# Altium schematic sheet Terra_Spray.SchDoc(Terra_Spray)
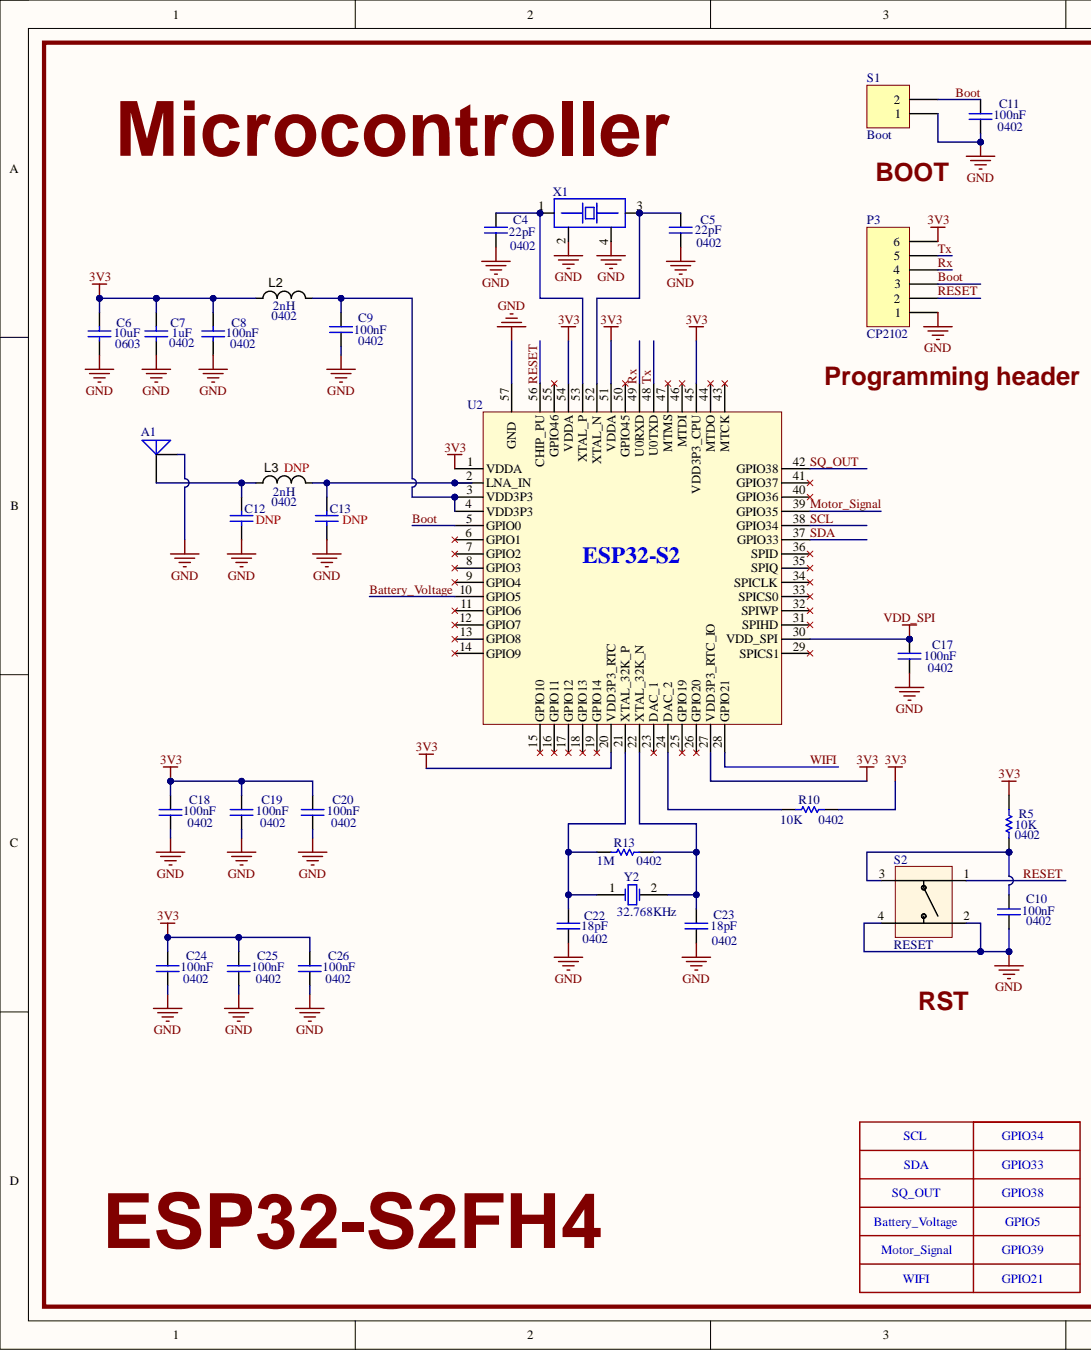
[diagram p0: Terra_Spray.SchDoc, left]
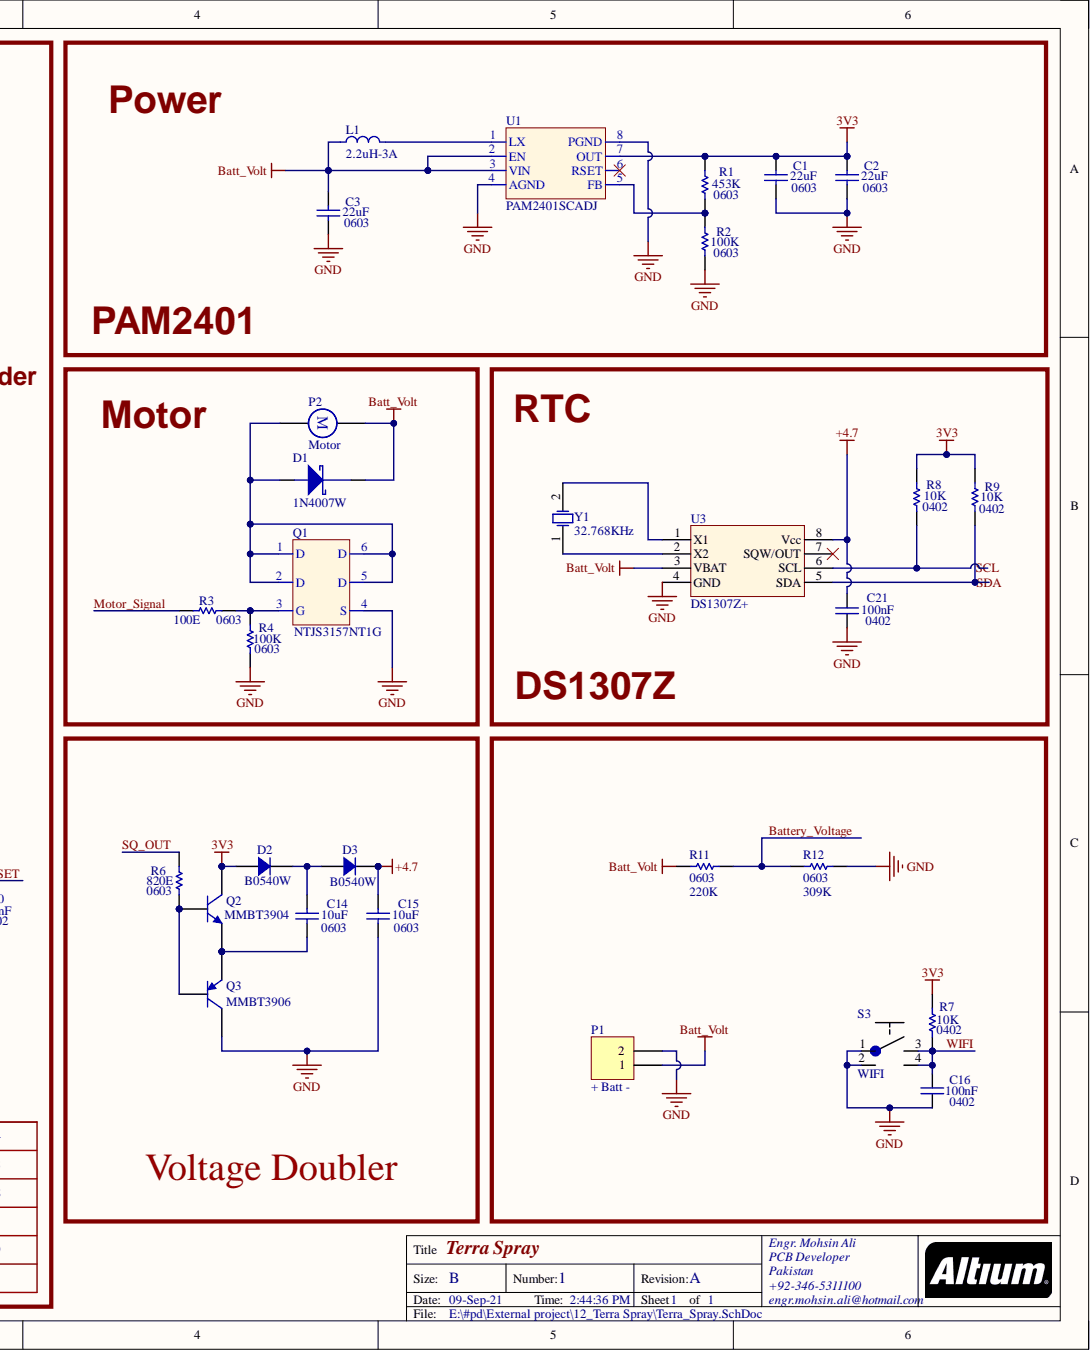
[diagram p1: Terra_Spray.SchDoc, right]

## Parts
A1  Antenna_1  [ESP32 ANT]  (value not drawn)
C1  value 22uF  Case/Package: 0603  [CAPC0603]  (hidden comment: Capacitor)
C2  value 22uF  Case/Package: 0603  [CAPC0603]  (hidden comment: Capacitor)
C3  value 22uF  Case/Package: 0603  [CAPC0603]  (hidden comment: Capacitor)
C4  value 22pF  Case/Package: 0402  [capc0402]  (hidden comment: Cap)
C5  value 22pF  Case/Package: 0402  [capc0402]  (hidden comment: Cap)
C6  value 10uF  Case/Package: 0603  [CAPC0603]  (hidden comment: Capacitor)
C7  value 1uF  Case/Package: 0402  [CAPC0402]  (hidden comment: Capacitor)
C8  value 100nF  Case/Package: 0402  [CAPC0402]  (hidden comment: Cap)
C9  value 100nF  Case/Package: 0402  [CAPC0402]  (hidden comment: Cap)
C10  value 100nF  Case/Package: 0402  [CAPC0402]  (hidden comment: Cap)
C11  value 100nF  Case/Package: 0402  [CAPC0402]  (hidden comment: Cap)
C12  Case/Package: 0402  [CAPC0402]  (hidden comment: Capacitor)  (no BOM)
C13  Capacitor  [CAPC0402]  (value not drawn)  (no BOM)
C14  value 10uF  Case/Package: 0603  [CAPC0603]  (hidden comment: Capacitor)
C15  value 10uF  Case/Package: 0603  [CAPC0603]  (hidden comment: Capacitor)
C16  value 100nF  Case/Package: 0402  [CAPC0402]  (hidden comment: Cap)
C17  value 100nF  Case/Package: 0402  [CAPC0402]  (hidden comment: Cap)
C18  value 100nF  Case/Package: 0402  [CAPC0402]  (hidden comment: Cap)
C19  value 100nF  Case/Package: 0402  [CAPC0402]  (hidden comment: Cap)
C20  value 100nF  Case/Package: 0402  [CAPC0402]  (hidden comment: Cap)
C21  value 100nF  Case/Package: 0402  [CAPC0402]  (hidden comment: Cap)
C22  value 18pF  Case/Package: 0402  [capc0402]  (hidden comment: Cap)
C23  value 18pF  Case/Package: 0402  [capc0402]  (hidden comment: Cap)
C24  value 100nF  Case/Package: 0402  [CAPC0402]  (hidden comment: Cap)
C25  value 100nF  Case/Package: 0402  [CAPC0402]  (hidden comment: Cap)
C26  value 100nF  Case/Package: 0402  [CAPC0402]  (hidden comment: Cap)
D1  1N4007W  [SOD-123]
D2  B0540W  [SOD123 - duplicate]
D3  B0540W  [SOD123 - duplicate]
L1  value 2.2uH-3A  [INDP5050X20N]  (hidden comment: Inductor)
L2  Case Code (Imperial): 0402  Inductance: 2nH  [inductor 0402]  (hidden comment: Inductor)
L3  Case Code (Imperial): 0402  Inductance: 2nH  [inductor 0402]  (hidden comment: Inductor)  (no BOM)
P1  + Batt -  [2 pin 2.5mm - Header]
P2  Motor  [2 pin 2.5mm - Header]
P3  CP2102  [Header 6x1]
Q1  Mosfet  [SOT-363]  (value not drawn)
Q2  Manufacturer Part Number: MMBT3904  [SOT-23]  (hidden comment: NPN BJT Transistor)
Q3  Manufacturer Part Number: MMBT3906  [SOT-23]  (hidden comment: PNP BJT Transistor)
R1  value 453K  Case/Package: 0603  [RESC0603]  (hidden comment: Resistor)
R2  value 100K  Case/Package: 0603  [RESC0603]  (hidden comment: Resistor)
R3  value 100E  Case/Package: 0603  [RESC0603]  (hidden comment: Resistor)
R4  value 100K  Case/Package: 0603  [RESC0603]  (hidden comment: Resistor)
R5  value 10K  Case/Package: 0402  [RESC0402]  (hidden comment: Resistor)
R6  value 820E  Case/Package: 0603  [RESC0603]  (hidden comment: Resistor)
R7  value 10K  Case/Package: 0402  [RESC0402]  (hidden comment: Resistor)
R8  value 10K  Case/Package: 0402  [RESC0402]  (hidden comment: Resistor)
R9  value 10K  Case/Package: 0402  [RESC0402]  (hidden comment: Resistor)
R10  value 10K  Case/Package: 0402  [RESC0402]  (hidden comment: Resistor)
R11  value 220K  Case/Package: 0603  [RESC0603]  (hidden comment: Resistor)
R12  value 309K  Case/Package: 0603  [RESC0603]  (hidden comment: Resistor)
R13  value 1M  Case/Package: 0402  [RESC0402]  (hidden comment: Resistor)
S1  Boot  [Switch Replacement]
S2  value RESET  [434153017835]  (hidden comment: Button)
S3  WIFI  [FSMJ - SMD - Wifi]
U1  PAM2401SCADJ  [8-TSSOP, 8-MSOP]
U2  ESP32-S2  [QFN56-7x7mm]
U3  DS1307Z+  Series: DS1307Z  [SOIC-8]
X1  CRYSTAL_1  [Crystal_3225]  (value not drawn)
Y1  32.768KHz  [32.728Khz]
Y2  32.768KHz  [32.728Khz]

## Nets
3V3: C1.2 C2.2 C6.2 C7.2 C8.2 C18.1 C19.1 C20.1 C24.1 C25.1 C26.1 D2.A L2.1 P3.6 Q2.3 R1.2 R5.2 R7.2 R8.2 R9.2 R10.1 U1.7(OUT) U2.1(VDDA) U2.20(VDD3P3_RTC) U2.27(VDD3P3_RTC_IO) U2.45(VDD3P3_CPU) U2.51(VDDA) U2.54(VDDA)
+4.7: C15.1 C21.1 D3.K U3.8(Vcc)
Batt_Volt: C3.2 D1.1 L1.1 P1.1 P2.1 R11.2 U1.2(EN) U1.3(VIN) U3.3(VBAT)
GND: A1.2 C1.1 C2.1 C3.1 C4.1 C5.1 C6.1 C7.1 C8.1 C9.2 C10.2 C11.1 C12.1 C13.1 C15.2 C16.1 C17.2 C18.2 C19.2 C20.2 C21.2 C22.1 C23.1 C24.2 C25.2 C26.2 P1.2 P3.1 Q1.4(S) Q3.3 R2.2 R4.2 R12.1 S1.1 S2.2 S2.4 S3.1 S3.2 U1.4(AGND) U1.8(PGND) U2.57(GND) U3.4(GND) X1.2 X1.4
VDD_SPI: C17.1 U2.30(VDD_SPI)
Battery_Voltage: R11.1 R12.2 U2.10(GPIO5)
Boot: C11.2 P3.3 S1.2 U2.5(GPIO0)
Motor_Signal: R3.2 U2.39(GPIO35)
RESET: P3.2 S2.1 U2.56(CHIP_PU)
Rx: P3.4 U2.49(U0RXD)
SCL: R8.1 U2.38(GPIO34) U3.6(SCL)
SDA: R9.1 U2.37(GPIO33) U3.5(SDA)
SQ_OUT: R6.2 U2.42(GPIO38)
Tx: P3.5 U2.48(U0TXD)
WIFI: C16.2 R7.1 S3.3 S3.4 U2.28(GPIO21)
NetA1_1: A1.1 C12.2 L3.1
NetC4_2: C4.2 U2.53(XTAL_P) X1.1
NetC5_2: C5.2 U2.52(XTAL_N) X1.3
NetC9_1: C9.1 L2.2 U2.3(VDD3P3) U2.4(VDD3P3)
NetC10_1: C10.1 R5.1 S2.3
NetC13_2: C13.2 L3.2 U2.2(LNA_IN)
NetC14_1: C14.1 D2.K D3.A
NetC14_2: C14.2 Q2.2 Q3.2
NetC22_2: C22.2 R13.1 U2.21(XTAL_32K_P) Y2.1
NetC23_2: C23.2 R13.2 U2.22(XTAL_32K_N) Y2.2
NetD1_2: D1.2 P2.2 Q1.1(D) Q1.2(D) Q1.5(D) Q1.6(D)
NetL1_2: L1.2 U1.1(LX)
NetQ1_3: Q1.3(G) R3.1 R4.1
NetQ2_1: Q2.1 Q3.1 R6.1
NetR1_1: R1.1 R2.1 U1.5(FB)
NetR10_2: R10.2 U2.24(DAC_2)
NetU3_1: U3.1(X1) Y1.2
NetU3_2: U3.2(X2) Y1.1
GPIO5:
GPIO21:
GPIO33:
GPIO34:
GPIO38:
GPIO39:

Not connected: U1 6(RSET); U2 6(GPIO1) 7(GPIO2) 8(GPIO3) 9(GPIO4) 11(GPIO6) 12(GPIO7) 13(GPIO8) 14(GPIO9) 15(GPIO10) 16(GPIO11) 17(GPIO12) 18(GPIO13) 19(GPIO14) 23(DAC_1) 25(GPIO19) 26(GPIO20) 29(SPICS1) 31(SPIHD) 32(SPIWP) 33(SPICS0) 34(SPICLK) 35(SPIQ) 36(SPID) 40(GPIO36) 41(GPIO37) 43(MTCK) 44(MTDO) 46(MTDI) 47(MTMS) 50(GPIO45) 55(GPIO46); U3 7(SQW/OUT)

## Library data of the parts
A1 = Antenna_1: PCB Antenna; Component Kind: Standard; LatestRevisionDate: 17-Jul-2002; LatestRevisionNote: Re-released for DXP Platform.; Library Name: Pd's Sch Lib.SchLib; PackageReference: PIN1; Published: 24-Mar-1999; Publisher: Altium Limited
C1-C3 = Capacitor: Ceramic Capacitor,22uF,0603,6.3V; Component Kind: Standard; Library Name: Pd's Sch Lib.SchLib
C4 C5 = Cap: Ceramic Capacitor,22pF,0402,50V; Component Kind: Standard; Library Name: CUSTOM TNY290PG.SchLib; Signal Integrity: Cap Pol; Simulation: CAP
C6 C14 C15 = Capacitor: Ceramic Capacitor,10uF,0603,10V; Component Kind: Standard; Library Name: Pd's Sch Lib.SchLib
C7 = Capacitor: Ceramic Capacitor,1uF,0402,6.3V; Component Kind: Standard; Library Name: Pd's Sch Lib.SchLib
C8 = Capacitor: Ceramic Capacitor,100nF,0402,6.3V; Component Kind: Standard; Library Name: Pd's Sch Lib.SchLib
C9 C10 C17-C20 C24-C26 = Cap: Ceramic Capacitor,100nF,0402,6.3V; Component Kind: Standard; Library Name: CUSTOM TNY290PG.SchLib
  C9 C10 C18-C20 C24-C26: Signal Integrity: Cap Pol; Simulation: CAP
C11 = Cap: Ceramic Capacitor,100nF,0402,16V; Component Kind: Standard; Library Name: CUSTOM TNY290PG.SchLib; Signal Integrity: Cap Pol; Simulation: CAP
C16 C21 = Cap: Ceramic Capacitor,100nF,0402,6.3  V; Component Kind: Standard; Library Name: CUSTOM TNY290PG.SchLib; Signal Integrity: Cap Pol; Simulation: CAP
C22 C23 = Cap: Ceramic Capacitor,18pF,0402,50V; Component Kind: Standard; Library Name: CUSTOM TNY290PG.SchLib; Signal Integrity: Cap Pol; Simulation: CAP
D1 = BAT54HT1G: Rectifiers RECTIFIER DIODE 1A 1000V; Part No: CGRM4007-G; Component Kind: Standard; ComponentLink1Description: http://www.fairchildsemi.com/ds/BA/BAT54HT1G.pdf; ComponentLink1URL: http://www.fairchildsemi.com/ds/BA/BAT54HT1G.pdf; Library Name: Integrated_Library_FEDEVEL_APR_2015.IntLib
D2 D3 = Diode: Diode Schottky 40V 0.5A 2-Pin SOD-123 T/R; Manufacturer 1: Diodes; Average Rectified Current: 500mA; Element Configuration: Single; Forward Voltage: 620mV; Max Forward Surge Current (Ifsm): 5.5A; Max Power Dissipation: 410mW; Max Reverse Current: 20uA; Component Kind: Standard; Forward Current: 500mA; Height: 1.25mm; Lead Free: Lead Free; Length: 2.85mm; Library Name: Pd's Sch Lib.SchLib; Manufacturer Part Number: B0540W; Max Operating Temperature: 150°C; Max Repetitive Reverse Voltage (Vrrm): 40V; Max Reverse Voltage (DC): 40V; Min Operating Temperature: -65°C; Mount: Surface Mount; Number of Pins: 2; Output Current: 500mA; Package Quantity: 3000
L1 = 74404052022: WURTH ELEKTRONIK - 74404052022 - Power Inductor (SMD), 2.2 µH, 3 A, Semishielded, 3.6 A, WE-LQS Series, 5mm x 5mm x 2mm; Manufacturer 1: Wurth Electronics; Current Rating: 3A; DC Resistance (DCR): 31.6mR; Inductance: 2.2uH; Saturation Current: 3.6A; Self Resonant Frequency: 88MHz; Test Frequency: 100kHz; Tolerance: 30%; Case/Package: Nonstandard; Component Kind: Standard; ComponentLink1Description: http://www.farnell.com/datasheets/2618696.pdf?src-supplier=Farnell; ComponentLink1URL: http://www.farnell.com/datasheets/2618696.pdf?src-supplier=Farnell; ComponentLink2Description: https://katalog.we-online.de/pbs/datasheet/74404052022.pdf?src-supplier=Digi-Key; ComponentLink2URL: https://katalog.we-online.de/pbs/datasheet/74404052022.pdf?src-supplier=Digi-Key; ComponentLink3Description: http://www.farnell.com/datasheets/2618696.pdf?src-supplier=Newark; ComponentLink3URL: http://www.farnell.com/datasheets/2618696.pdf?src-supplier=Newark; DigiKey: 732-7241-1-ND; Height - Seated (Max): 0.079inch; Length: 0.197inch; Library Name: Inductors.SchLib; Max Operating Temperature: 125°C; Min Operating Temperature: -40°C; Mount: Surface Mount; P/N: 74404052022; Packaging: Tape and Reel; Pricing 1: 1=1.23000, 50=1.13100, 250=1.06400, 500=0.95200, 1000=0.78400 (USD); Resistor Type: Wirewound; RoHS: Yes; Shielding: Shielded; Stock 1: 1338; Supplier 1: Digi-Key; Width: 0.197inch
L2 L3 = Inductor: 2nH ±0.3nH 900mA 120mΩ 0402 Inductors (SMD) RoHS; Manufacturer 1: Murata; Manufacturer Part Number: LQG15HN2N0S02D; Category 1: Fixed Inductors; Core Material: Air; DC Resistance (DCR): 120mR; Description 1: Fixed Inductors 0402 2.0nH; Q Factor: 8; Self Resonant Frequency: 6GHz; Shielding: Unshielded; Test Frequency: 100MHz; Case Code (Metric): 1005; Case/Package: 0402; ComponentLink1Description: https://datasheet.ciiva.com/11799/0900766b8090d3b8-11799042.pdf?src-supplier=RSComponents; ComponentLink1URL: https://datasheet.ciiva.com/11799/0900766b8090d3b8-11799042.pdf?src-supplier=RSComponents; ComponentLink2Description: https://datasheet.ciiva.com/4976/o05e-4976477.pdf?src-supplier=Mouser; ComponentLink2URL: https://datasheet.ciiva.com/4976/o05e-4976477.pdf?src-supplier=Mouser; ComponentLink3Description: https://datasheet.ciiva.com/8510/l0075s0083lqg15hn-8510907.pdf?src-supplier=Avnet; ComponentLink3URL: https://datasheet.ciiva.com/8510/l0075s0083lqg15hn-8510907.pdf?src-supplier=Avnet; ComponentLink4Description: https://datasheet.ciiva.com/17279/l0075s0083lqg15hn-17279196.pdf?src-supplier=Avnet+Europe; ComponentLink4URL: https://datasheet.ciiva.com/17279/l0075s0083lqg15hn-17279196.pdf?src-supplier=Avnet+Europe; Current: 900mA; Current Rating: 900mA; DC Current: 900mA; Depth: 0.55mm; Height: 0.5mm; Height - Seated (Max): 0.022inch; Length: 1mm; Library Name: Pd's Sch Lib.SchLib; Max DC Current: 900mA; Max Operating Temperature: 125°C; Military Standard: Not; Min Operating Temperature: -55°C; Mount: Surface Mount; Number of Pins: 2; Package Quantity: 10000; Packaging: Tape and Reel; Pricing 1: 50=0.00840, 500=0.00620, 1500=0.00580, 5000=0.00540, 25000=0.00520, 50000=0.00520, 50=0.00810, 500=0.00600, 1500=0.00560, 5000=0.00520, 25000=0.00500, 50000=0.00500, 50=0.04180, 500=0.03090, 1500=0.02890, 5000=0.02690, 25000=0.02600, 50000=0.02550, 50=0.03860, 500=0.02850, 1500=0.02670, 5000=0.02480, 25000=0.02400, 50000=0.02360, 50=0.00520, 500=0.00380, 1500=0.00360, 5000=0.00330, 25000=0.00320, 50000=0.00320, 50=0.00480, 500=0.00350, 1500=0.00330, 5000=0.00310, 25000=0.00300, 50000=0.00290, 50=0.04770, 500=0.03530, 1500=0.03300, 5000=0.03070, 25000=0.02970, 50000=0.02920, 50=0.65070, 500=0.48080, 1500=0.44960, 5000=0.41830, 25000=0.40450, 50000=0.39760, 50=0.02550, 500=0.01880, 1500=0.01760, 5000=0.01640, 25000=0.01580, 50000=0.01560, 50=0.46320, 500=0.34230, 1500=0.32010, 5000=0.29780, 25000=0.28800, 50000=0.28310, 50=0.05400, 500=0.03990, 1500=0.03730, 5000=0.03470, 25000=0.03360, 50000=0.03300, 50=0.00840, 500=0.00620, 1500=0.00580, 5000=0.00540, 25000=0.00520, 50000=0.00510, 50=0.00616, 500=0.00455, 1500=0.00426, 5000=0.00396, 25000=0.00383, 50000=0.00376 (AUD); Radiation Hardening: No; REACH SVHC: No SVHC; RoHS 1: Yes; Stock 1: 84500; Supplier 1: LCSC; Supplier Part Number 1: C97984; Termination: SMD/SMT; Width: 0.02inch
  L2: Component Kind: Standard
P1 S1 = Header 2: Header, 2-Pin; Component Kind: Standard; LatestRevisionDate: 17-Jul-2002; LatestRevisionNote: Re-released for DXP Platform.; Library Name: Miscellaneous Connectors.IntLib; Signal Integrity: Connector; Publisher: Altium Limited
P2 = Motor: Motor, General Kind; Component Kind: Standard; LatestRevisionDate: 17-Jul-2002; LatestRevisionNote: Re-released for DXP Platform.; Library Name: Miscellaneous Devices.IntLib; PackageDescription: Capacitor (Radial); 2 Leads; PackageReference: RB5-10.5; Published: 24-Mar-1999; Publisher: Altium Limited
P3 = Header 6: Header, 6-Pin; Component Kind: Standard; LatestRevisionDate: 17-Jul-2002; LatestRevisionNote: Re-released for DXP Platform.; Library Name: Miscellaneous Connectors.IntLib; Signal Integrity: Connector; Publisher: Altium Limited
Q1 = NTJS3157NT1G: N-Channel 20V 3.2A (Ta) 1W (Ta) Surface Mount SC-88/SC70-6/SOT-363; Component Kind: Standard; Part No: NTJS3157NT1G; Library Name: Terra_Spray.SchLib
Q2 = NPN BJT Transistor: Bipolar Transistors - BJT SOT-23 NPN; Current Rating: 200mA; Max Breakdown Voltage: 40V; Max Power Dissipation: 350mW; Case/Package: SOT-23; Category: BJTs; Component Kind: Standard; ComponentLink1Description: https://datasheet.ciiva.com/971/mmbt3904-971892.pdf?src-supplier=Digi-Key; ComponentLink1URL: https://datasheet.ciiva.com/971/mmbt3904-971892.pdf?src-supplier=Digi-Key; ComponentLink2Description: https://datasheet.ciiva.com/971/mmbt3904-971893.pdf?src-supplier=Digi-Key; ComponentLink2URL: https://datasheet.ciiva.com/971/mmbt3904-971893.pdf?src-supplier=Digi-Key; ComponentLink3Description: https://datasheet.ciiva.com/22653/1922793-22653482.pdf?src-supplier=Element14; ComponentLink3URL: https://datasheet.ciiva.com/22653/1922793-22653482.pdf?src-supplier=Element14; ComponentLink4Description: https://datasheet.ciiva.com/971/mmbt3904-971891.pdf?src-supplier=Digi-Key; ComponentLink4URL: https://datasheet.ciiva.com/971/mmbt3904-971891.pdf?src-supplier=Digi-Key; ComponentLink5Description: https://datasheet.ciiva.com/26237/mmbt3904-26237242.pdf?src-supplier=Avnet+Europe; ComponentLink5URL: https://datasheet.ciiva.com/26237/mmbt3904-26237242.pdf?src-supplier=Avnet+Europe; ComponentLink6Description: https://datasheet.ciiva.com/7972/2n3904-7972669.pdf?src-supplier=Element14; ComponentLink6URL: https://datasheet.ciiva.com/7972/2n3904-7972669.pdf?src-supplier=Element14; ComponentLink7Description: https://datasheet.ciiva.com/16850/mmbt3904-16850397.pdf?src-supplier=Future+Electronics; ComponentLink7URL: https://datasheet.ciiva.com/16850/mmbt3904-16850397.pdf?src-supplier=Future+Electronics; ComponentLink8Description: https://datasheet.ciiva.com/11201/mmbt3904-11201775.pdf?src-supplier=Avnet; ComponentLink8URL: https://datasheet.ciiva.com/11201/mmbt3904-11201775.pdf?src-supplier=Avnet; Library Name: Pd's Sch Lib.SchLib; Max Collector Current: 200mA; Min Operating Temperature: -55°C; Mount: Surface Mount; Polarity: NPN; Power Dissipation: 350mW; Termination: SMD/SMT
Q3 = PNP BJT Transistor: ON SEMICONDUCTOR - MMBT3906LT1G - Bipolar (BJT) Single Transistor, General Purpose, PNP, -40 V, 250 MHz, 225 mW, -200 mA, 300 hFE; Collector Base Voltage (VCBO): 40V; Collector Emitter Saturation Voltage: -400mV; Current Rating: -200mA; Emitter Base Voltage (VEBO): 5V; Frequency: 250MHz; hFE Min: 60; Max Collector Current: 200mA; Max Power Dissipation: 300mW; Number of Elements: 1; Voltage Rating (DC): -40V; Case/Package: SOT-23-3; Category: BJTs; Collector Emitter Breakdown Voltage: 40V; Collector Emitter Voltage (VCEO): 40V; Component Kind: Standard; ComponentLink1Description: Manufacturer Link; ComponentLink1URL: http://www.fairchildsemi.com/; ComponentLink2Description: Datasheet; ComponentLink2URL: http://www.fairchildsemi.com/ds/2N%2F2N3906.pdf; ComponentLink3Description: https://datasheet.ciiva.com/22664/1708270-22664162.pdf?src-supplier=Element14; ComponentLink3URL: https://datasheet.ciiva.com/22664/1708270-22664162.pdf?src-supplier=Element14; ComponentLink4Description: https://datasheet.ciiva.com/25998/mmbt3906lt1-d-25998821.pdf?src-supplier=Avnet+Europe; ComponentLink4URL: https://datasheet.ciiva.com/25998/mmbt3906lt1-d-25998821.pdf?src-supplier=Avnet+Europe; ComponentLink5Description: https://datasheet.ciiva.com/976/mmbt3906lt1-d-976359.pdf?src-supplier=Digi-Key; ComponentLink5URL: https://datasheet.ciiva.com/976/mmbt3906lt1-d-976359.pdf?src-supplier=Digi-Key; ComponentLink6Description: https://datasheet.ciiva.com/16387/mmbt3906lt1-d-16387191.pdf?src-supplier=Future+Electronics; ComponentLink6URL: https://datasheet.ciiva.com/16387/mmbt3906lt1-d-16387191.pdf?src-supplier=Future+Electronics; ComponentLink7Description: https://datasheet.ciiva.com/976/mmbt3906lt1-d-976360.pdf?src-supplier=Digi-Key; ComponentLink7URL: https://datasheet.ciiva.com/976/mmbt3906lt1-d-976360.pdf?src-supplier=Digi-Key; ComponentLink8Description: https://datasheet.ciiva.com/976/mmbt3906lt1-d-976361.pdf?src-supplier=Digi-Key; ComponentLink8URL: https://datasheet.ciiva.com/976/mmbt3906lt1-d-976361.pdf?src-supplier=Digi-Key; ComponentLink9Description: https://datasheet.ciiva.com/11201/mmbt3906lt1-d-11201803.pdf?src-supplier=Avnet; ComponentLink9URL: https://datasheet.ciiva.com/11201/mmbt3906lt1-d-11201803.pdf?src-supplier=Avnet; ComponentLink10Description: https://datasheet.ciiva.com/4721/mmbt3906lt1-d-4721423.pdf?src-supplier=Mouser; ComponentLink10URL: https://datasheet.ciiva.com/4721/mmbt3906lt1-d-4721423.pdf?src-supplier=Mouser; Contact Plating: Tin,Matte; Element Configuration: Single; Gain Bandwidth Product: 250MHz; Height: 0.94mm; Lead Free: Lead Free; Length: 2.9mm; Library Name: Pd's Sch Lib.SchLib; Manufacturer: ON Semiconductor; Max Breakdown Voltage: 40V; Max Frequency: 250MHz; Max Operating Temperature: 150°C; Min Operating Temperature: -55°C; Number of Pins: 3; Package Quantity: 1; Packaging: Tape and Reel; Polarity: PNP; Power Dissipation: 300mW; Pricing 1: 1=0.13000, 10=0.09200, 25=0.08800, 100=0.04960, 500=0.03892, 1000=0.02703 (USD); Radiation Hardening: No; REACH SVHC: No SVHC; RoHS: Yes; Signal Integrity: 2N3906; Simulation: 2N3906; Stock 1: 148812; Supplier 1: Digi-Key; Transition Frequency: 250MHz; Width: 1.3mm
R1 = Resistor: Thick Film Resistor -  453Kohm, 1%, 0603; Component Kind: Standard; Library Name: Pd's Sch Lib.SchLib
R2 R4 = Resistor: Thick Film Resistor -  100Kohm, 1%, 0603; Component Kind: Standard; Library Name: Pd's Sch Lib.SchLib
R3 = Resistor: Thick Film Resistor -  100ohm, 1%, 0603; Component Kind: Standard; Library Name: Pd's Sch Lib.SchLib
R5 R7-R10 = Resistor: Thick Film Resistor -  10Kohm, 1%, 0402; Component Kind: Standard; Library Name: Pd's Sch Lib.SchLib
R6 = Resistor: Thick Film Resistor -  820ohm, 1%, 0603; Component Kind: Standard; Library Name: Pd's Sch Lib.SchLib
R11 = Resistor: Thick Film Resistor -  220Kohm, 1%, 0603; Component Kind: Standard; Library Name: Pd's Sch Lib.SchLib
R12 = Resistor: Thick Film Resistor -  309Kohm, 1%, 0603; Component Kind: Standard; Library Name: Pd's Sch Lib.SchLib
R13 = Resistor: Thick Film Resistor -  1Mohm, 1%, 0402; Component Kind: Standard; Library Name: Pd's Sch Lib.SchLib
S2 = 434153017835: SWITCH TACTILE SPST-NO 0.05A 12V; Manufacturer: Wurth Electronics; Actuator Material: Polymer,Liquid Crystal Polymer; Illumination Type: Non-Illuminated; Mechanical Life: 200000Cycles; Operating Force: 350gf; Switch Function: Off-Mom; Switch Travel: 0.15mm; Throw Configuration: SPST; Component Kind: Standard; ComponentLink1Description: https://datasheet.ciiva.com/52202/434153017835-52202871.pdf?src-supplier=Digi-Key; ComponentLink1URL: https://datasheet.ciiva.com/52202/434153017835-52202871.pdf?src-supplier=Digi-Key; Contact Material: Steel; DigiKey: 732-10143-1-ND; Library Name: Buttons.IntLib; Max Operating Temperature: 85°C; Min Operating Temperature: -40°C; Mount: Surface Mount; P/N: 434153017835; Packaging: Tape and Reel; Pricing 1: 1=0.56000, 100=0.47150, 500=0.41400, 1000=0.33350 (USD); RoHS: Yes; Stock 1: 48125; Supplier 1: Digi-Key
S3 = FSM14J: Pushbutton 6x6mm, Actuator Height off PCB, Vertical 9.50mm; Manufacturer Part Number: MJTP1138BTUBE; MF: TE Connectivity; Availability: Unavailable; Component Kind: Standard; Digi-Key Part Number: MJTP1138BTUBE-ND; Library Name: Main_PCB.SchLib; MP: FSM14J; Package: None; Price: None; SnapEDA_Link: https://www.snapeda.com/parts/FSM14J/TE%20Connectivity%20ALCOSWITCH%20Switches/view-part/?ref=snap
U1 = PAM2401SCADJ: IC REG BOOST ADJ 3A SYNC 8MSOP; Manufacturer 1: Diodes; Max Input Voltage: 4.75V; Max Output Voltage: 5V; Min Input Voltage: 900mV; Min Output Voltage: 2.5V; Number of Outputs: 1; Operating Supply Current: 1uA; Output Configuration: Positive; Output Type: Adjustable; Switching Frequency: 1MHz; Synchronous Rectifier: Yes; Case/Package: MSOP; Component Kind: Standard; ComponentLink1Description: http://www.mouser.com/ds/2/115/PAM2401-347378.pdf?src-supplier=Mouser; ComponentLink1URL: http://www.mouser.com/ds/2/115/PAM2401-347378.pdf?src-supplier=Mouser; ComponentLink2Description: https://www.diodes.com/assets/Datasheets/PAM2401.pdf?src-supplier=Digi-Key; ComponentLink2URL: https://www.diodes.com/assets/Datasheets/PAM2401.pdf?src-supplier=Digi-Key; ComponentLink3Description: http://diodes.com/datasheets/PAM2401.pdf?src-supplier=Avnet; ComponentLink3URL: http://diodes.com/datasheets/PAM2401.pdf?src-supplier=Avnet; DigiKey: PAM2401SCADJDICT-ND; Lead Free: Lead Free; Library Name: Regulators.IntLib; Manufacturer Part Number: PAM2401SCADJ; Max Operating Temperature: 85°C; Min Operating Temperature: -40°C; Min Supply Voltage: 900mV; Mount: Surface Mount; Number of Pins: 8; Output Current: 3A; Package Quantity: 2500; Packaging: Tape and Reel; Pricing 1: 1=0.99000, 10=0.88500, 25=0.84000, 100=0.64500, 250=0.57000, 500=0.54000, 1000=0.42000 (USD); RoHS: Yes; Stock 1: 42065; Supplier 1: Digi-Key; Topology: Boost; Weight: 0.004938oz
U2 = ESP32-S2: WiFi 802.11b/g/n Transceiver Module 2.4GHz; Manufacturer 1: Espressif Systems; Manufacturer Part Number: ESP32-S2FH4; Case/Package: QFN-56; Component Kind: Standard; Library Name: Pd's Sch Lib.SchLib
U3 = DS1307Z+: SERIAL TIME CHIP 8PIN, SOIC; Manufacturer 1: Maxim; Date Format: YY-MM-DD; Frequency: 32.768kHz; Interface: I2C,Serial,2-Wire; Logic Function: Clock; Max Supply Voltage: 5.5V; Memory Size: 56B; Memory Type: RAM; Min Supply Voltage: 4.5V; Number of Outputs: 1; Operating Supply Current: 1.5mA; Operating Supply Voltage: 5V; Time Format: HH:MM:SS; Case/Package: SOIC; Component Kind: Standard; ComponentLink1Description: https://datasheet.ciiva.com/20167/170004-da-en-01-20167204.pdf?src-supplier=Rapid+Electronics; ComponentLink1URL: https://datasheet.ciiva.com/20167/170004-da-en-01-20167204.pdf?src-supplier=Rapid+Electronics; ComponentLink2Description: https://datasheet.ciiva.com/1491/ds1307-1491905.pdf?src-supplier=Element14; ComponentLink2URL: https://datasheet.ciiva.com/1491/ds1307-1491905.pdf?src-supplier=Element14; ComponentLink3Description: https://datasheet.ciiva.com/1498/ds1307-1498866.pdf?src-supplier=Farnell; ComponentLink3URL: https://datasheet.ciiva.com/1498/ds1307-1498866.pdf?src-supplier=Farnell; ComponentLink4Description: https://datasheet.ciiva.com/1139/46359-1139142.pdf?src-supplier=Element14; ComponentLink4URL: https://datasheet.ciiva.com/1139/46359-1139142.pdf?src-supplier=Element14; ComponentLink5Description: https://datasheet.ciiva.com/14085/ds1307-14085608.pdf?src-supplier=Digi-Key; ComponentLink5URL: https://datasheet.ciiva.com/14085/ds1307-14085608.pdf?src-supplier=Digi-Key; ComponentLink6Description: https://datasheet.ciiva.com/3304/ds1307-3304457.pdf?src-supplier=Avnet; ComponentLink6URL: https://datasheet.ciiva.com/3304/ds1307-3304457.pdf?src-supplier=Avnet; ComponentLink7Description: https://datasheet.ciiva.com/14577/70127931-14577227.pdf?src-supplier=Allied+Electronics; ComponentLink7URL: https://datasheet.ciiva.com/14577/70127931-14577227.pdf?src-supplier=Allied+Electronics; Contact Plating: Tin,Matte; DigiKey: DS1307Z+-ND; Height: 1.5mm; Lead Free: Lead Free; Length: 5mm; Library Name: IC's.IntLib; Manufacturer Part Number: DS1307Z+; Max Operating Temperature: 70°C; Min Operating Temperature: 0°C; Mount: Surface Mount; Number of Pins: 8; Package Quantity: 100; Packaging: Rail/Tube; Pricing 1: 1=3.82000, 10=3.43200, 25=3.24480, 100=2.81200, 250=2.66780, 500=2.39380, 1000=2.26500 (USD); Radiation Hardening: No; REACH SVHC: No SVHC; RoHS: Yes; RoHS Compliant: Yes; Stock 1: 492; Supplier 1: Digi-Key; Voltage: 5V; Weight: 0.019048oz; Width: 4mm
X1 = CRYSTAL_1_1: 40MHz ±10ppm 10pF 30Ω SMD-3225 SMD Crystal Resonators RoHS; Manufacturer Part Number: 7M40000049; Case/Package: SMD-3225; Component Kind: Standard; ComponentLink1Description: https://datasheet.ciiva.com/3852/part27-3852098.pdf?src-supplier=Arrow+Electronics; ComponentLink1URL: https://datasheet.ciiva.com/3852/part27-3852098.pdf?src-supplier=Arrow+Electronics; ComponentLink2Description: https://datasheet.ciiva.com/852/p79e-852307.pdf?src-supplier=Digi-Key; ComponentLink2URL: https://datasheet.ciiva.com/852/p79e-852307.pdf?src-supplier=Digi-Key; ComponentLink3Description: https://datasheet.ciiva.com/852/p79e-852308.pdf?src-supplier=Digi-Key; ComponentLink3URL: https://datasheet.ciiva.com/852/p79e-852308.pdf?src-supplier=Digi-Key; ComponentLink4Description: https://datasheet.ciiva.com/1369/l0445e-1369908.pdf?src-supplier=Farnell; ComponentLink4URL: https://datasheet.ciiva.com/1369/l0445e-1369908.pdf?src-supplier=Farnell; ComponentLink5Description: https://datasheet.ciiva.com/852/p79e-852309.pdf?src-supplier=Digi-Key; ComponentLink5URL: https://datasheet.ciiva.com/852/p79e-852309.pdf?src-supplier=Digi-Key; ComponentLink6Description: https://datasheet.ciiva.com/1361/p79e-1361573.pdf?src-supplier=Element14; ComponentLink6URL: https://datasheet.ciiva.com/1361/p79e-1361573.pdf?src-supplier=Element14; ComponentLink7Description: https://datasheet.ciiva.com/1369/l0445e-1369909.pdf?src-supplier=Farnell; ComponentLink7URL: https://datasheet.ciiva.com/1369/l0445e-1369909.pdf?src-supplier=Farnell; ComponentLink8Description: https://datasheet.ciiva.com/1392/p79e-1392982.pdf?src-supplier=Newark; ComponentLink8URL: https://datasheet.ciiva.com/1392/p79e-1392982.pdf?src-supplier=Newark; Frequency: 40MHz; Library Name: Pd's Sch Lib.SchLib
Y1 Y2 = XTAL: Crystal Oscillator; P/N: X308032768KGB2SC; Component Kind: Standard; LatestRevisionDate: 17-Jul-2002; LatestRevisionNote: Re-released for DXP Platform.; Library Name: Miscellaneous Devices.IntLib; PackageDescription: Crystal, Thru-Hole; 2 Leads; Body 3.1 x 8.2 mm (Dia.xH); PackageReference: R38; Published: 8-Jun-2000; Simulation: XTAL; Publisher: Altium Limited
C12 C13 = Capacitor: Library Name: Pd's Sch Lib.SchLib
  C13: Case/Package: 0402
